# Altium schematic sheet Outputs Schematic.SchDoc("Outputs Schematic")
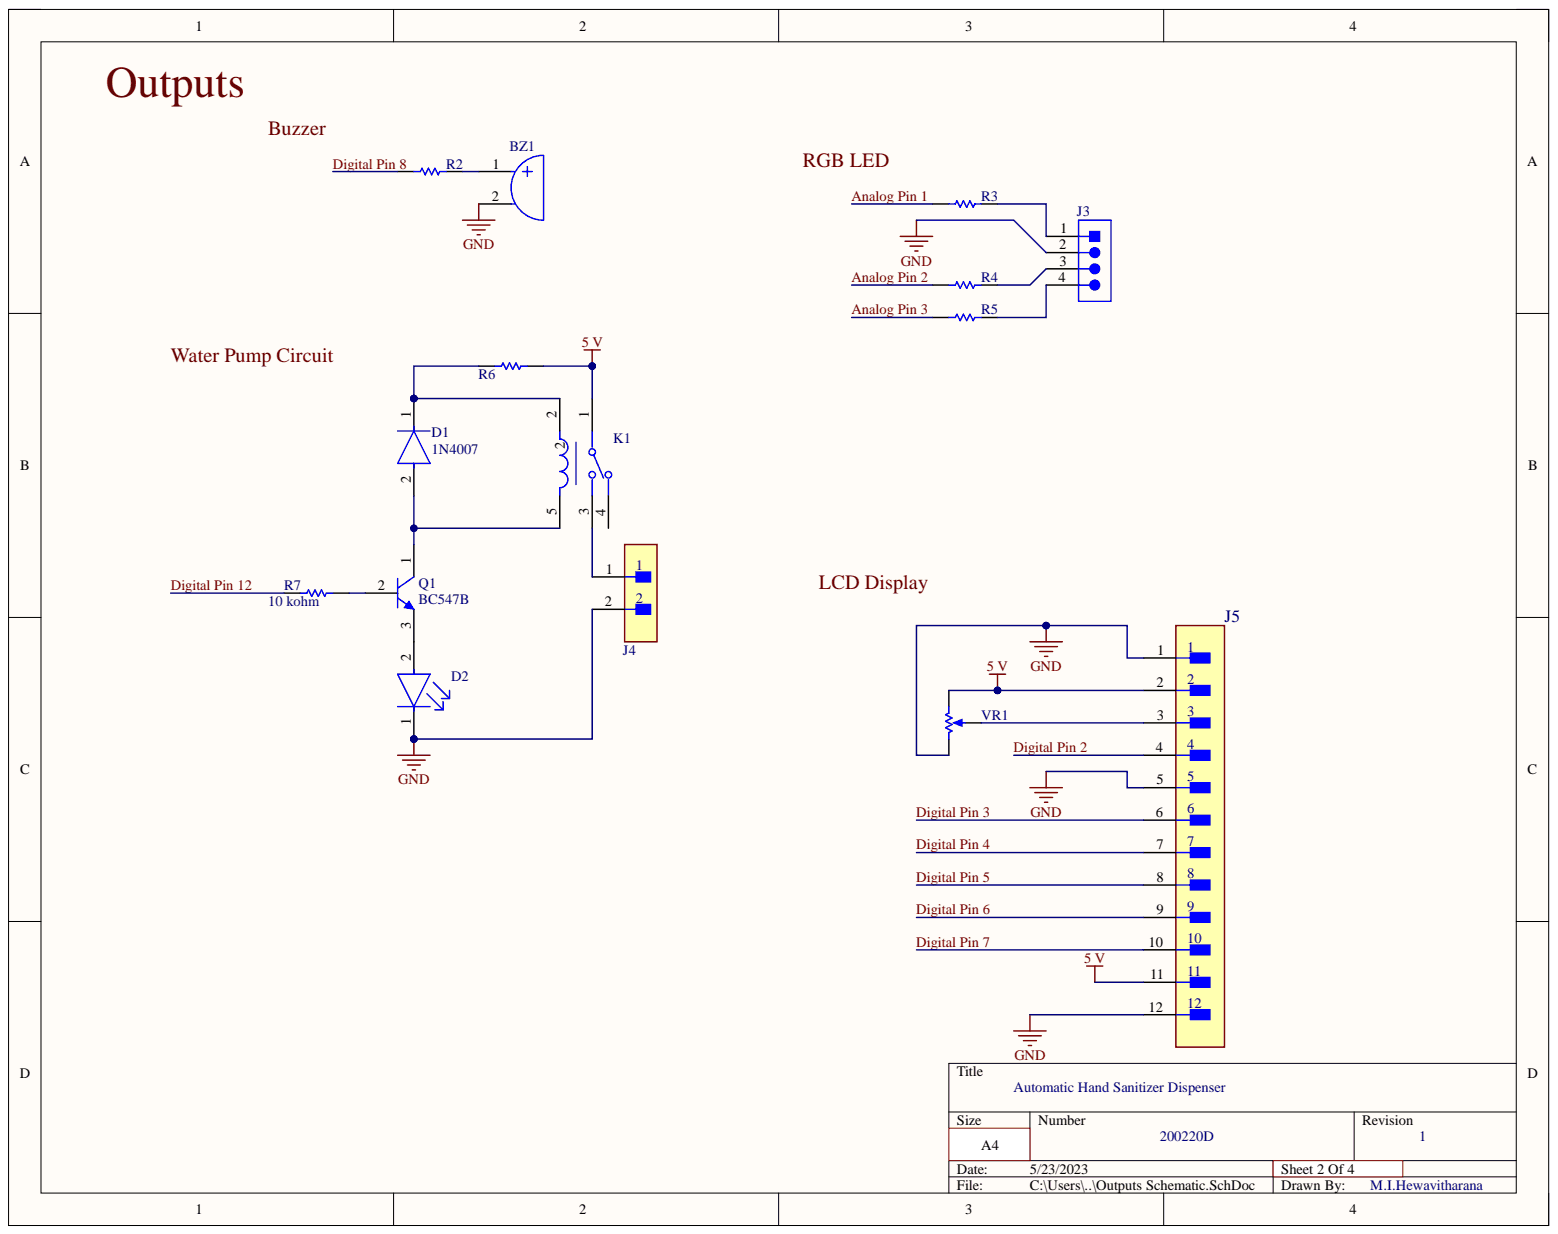
[diagram p0: Outputs Schematic.SchDoc]

## Parts
BZ1  KPEG242  [KINGS-KPEG242-2]  (value not drawn)
D1  1N4007  [FP-1N4007-MFG]
D2  SFH 4550  [FP-SFH_4550-MFG]  (value not drawn)
J3  640456-4  [TECO-640456-4]  (value not drawn)
J4  TSW-102-07-L-S  [FP-TSW-102-07-L-S-MFG]  (value not drawn)
J5  22-27-2121  [FP-22-27-2121-MFG]  (value not drawn)
K1  JS1-18V-F  [FP-JS1-18V-F-MFG]  (value not drawn)
Q1  BC547B  [ONSC-TO-92-3-29-11]
R2  MFR-25FBF52-1K  [RESA60-630X240]  (value not drawn)
R3  MFR-25FBF52-1K  [RESA60-630X240]  (value not drawn)
R4  MFR-25FBF52-1K  [RESA60-630X240]  (value not drawn)
R5  MFR-25FBF52-1K  [RESA60-630X240]  (value not drawn)
R6  MFR-25FBF52-1K  [RESA60-630X240]  (value not drawn)
R7  Resistance: 10 kohm  [RESA60-630X240]  (hidden comment: MFR-25FBF52-1K)
VR1  3852A-282-103AL  [BOUR-3852A-282-103AL-3_V]  (value not drawn)

## Nets
5 V: J5.2 J5.11 K1.1 R6.2 VR1.1
GND: BZ1.2 D2.1 J3.2 J4.2 J5.1 J5.5 J5.12 VR1.3
Analog Pin 1: R3.1
Analog Pin 2: R4.1
Analog Pin 3: R5.1
Digital Pin 2: J5.4
Digital Pin 3: J5.6
Digital Pin 4: J5.7
Digital Pin 5: J5.8
Digital Pin 6: J5.9
Digital Pin 7: J5.10
Digital Pin 8: R2.1
Digital Pin 12: R7.1
NetBZ1_1: BZ1.1 R2.2
NetD1_1: D1.1 K1.2 R6.1
NetD1_2: D1.2 K1.5 Q1.1
NetD2_2: D2.2 Q1.3
NetJ3_1: J3.1 R3.2
NetJ3_3: J3.3 R4.2
NetJ3_4: J3.4 R5.2
NetJ4_1: J4.1 K1.3
NetJ5_3: J5.3 VR1.2
NetQ1_2: Q1.2 R7.2

Not connected: K1 4

## Library data of the parts
BZ1 = CMP-2000-06518-1: Piezo Indicator, 4100 Hz, 3 to 16 V, -20 to 70 degC, 2-Pin THD, RoHS; Manufacturer: KingstateElectronicsCorp.; PartNumber: KPE-242; Current - Max: 7A; Frequency Range: 3600to4600Hz; Maximum Resonant Frequency: 4600Hz; Maximum Supply Current: 7mA; Minimum Sound Pressure: 70dBA; Product Diameter: 13.7mm; Product Height: 7.6mm; Tone Mode: Continuous; Typical Operating Supply Voltage: 12Vdc; Category: AudioIndicatorsandAlerts; Component Kind: Standard; Datasheet URL: https://datasheet.ciiva.com/11776/0900766b8068f3a2-11776713.pdf; Datasheet Version: 01/2006; Library Name: Altium Content Vault; Manufacturer URL: http://www.kingstate.com.tw/index.php/en/; Mounting: ThroughHole; Mounting Technology: ThroughHole; Operating Temperature: -20to70°C; Package Description: 2-Pin Through Hole Device, Body Dia 13.7 mm, Pitch 7.62 mm; Package Reference: KPEG242; Technology: Piezo; Termination Method: Pin; Type: Indicator
D1 = CMP-123542-000001-1: Rectifier diode 1000 V 1 A DO-41, ,; Manufacturer: Diotec; Capacitance: 15pF; Forward Current: 1A; Max Junction Temp: +175°C; Max Repetitive Reverse Voltage: 1000V; Max Surge Forward Current: 27A; Peak Reverse Current: 5uA; Value: 15pF; Automotive: No; Category: Diode; Component Kind: Standard; ComponentLink2Description: Datasheet; ComponentLink2URL: https://datasheet.octopart.com/1N4007-Diotec-datasheet-62346875.pdf; Device Class L1: Discrete Semiconductors; Device Class L2: Diodes; Device Class L3: Rectifier Diodes; Height: 2.8mm; Ipc Land Pattern Name: DIOAD888W100L520D280; Lead Free: Yes; Library Name: Altium Content Vault; Manufacturer Part Number: 1N4007; Octopart: https://octopart.com/1n4007-diotec-4040411; Package: 1N4007; Reverse Voltage: 1000V; RoHS: TRUE; Temperature: -50°C to +175°C; Temperature Range High: +175°C; Temperature Range Low: -50°C
D2 = CMP-62016-000011-1: EMITTER IR 860NM 100MA RADIAL; Manufacturer: Osram Opto; Colour: Infrared; Forward Voltage: 1.5V; Led Orientation: Straight; Reverse Voltage: 5V; Viewing Angle: 6°; Automotive: No; Category: Diode; Component Kind: Standard; ComponentLink2Description: Datasheet; ComponentLink2URL: https://dammedia.osram.info/media/resource/hires/osram-dam-5580407/SFH%204550_EN.pdf; Device Class L1: Optoelectronics; Device Class L2: LEDs; Device Class L3: unset; Digikey Description: EMITTER IR 860NM 100MA RADIAL; Forward Current: 100mA; Lead Free: Yes; Library Name: Altium Content Vault; Manufacturer Part Number: SFH 4550; Mouser Description: Infrared Emitters - High Power Infrared 850 nm HALF ANGLE +/-3DEG; Octopart: https://octopart.com/sfh+4550-osram+opto-55410894; Package: SFH 4550; Peak Emmision Wavelength: 860nm; RoHS: TRUE; Temperature: -40°C to +100°C; Temperature Range High: +100°C; Temperature Range Low: -40°C
J3 = CMP-2000-05419-1: Male Header, Pitch 2.54 mm, 1 x 4 Position, Height 10.03 mm, Tail Length 3.56 mm, RoHS, Bulk; Manufacturer: TE Connectivity; Agency Approvals: CSA,UL; Angle: Straight; Brand/Series: MTA-100Series; Color, Housing: White; Connector Type: MTA-100; Contact Centerline Spacing: 0.100    in.; Contact Termination Area Plating: Tin; Current, Rating: 5    A; Material, Contact: CopperAlloy; Material, Housing: Polyester; Mount Angle: Vertical; Mounting Hole Size: 0.04    "; Number of Contacts: 4; Pin Spacing: 0.1    "; Primary Type: InterconnectSystem; Special Features: Pinstrip; Standards: RoHSCompliant,ELVCompliant,UL94V-0; Temperature, Operating: -55to+105    degC; Voltage, Rating: 250    V; Color: White; Component Kind: Standard; Contact Mating Area Plating: Tin; Contact Plating: Tin; Contact Shape: Square; Datasheet URL: https://datasheet.ciiva.com/16286/eng-ds-82056-mta-0608-16286228.pdf; Datasheet Version: Dec-14; Flammability Rating: UL94V-0; Length, Overall: 0.4    "; Length, Pin: 0.14    "; Length, Terminal Post: 0.14    in.; Library Name: Altium Content Vault; Manufacturer URL: http://www.te.com/; Mounting Type: PCMount; Number of Positions: 4; Number of Rows: 1; Size, Post: 0.025    in.; Termination: Solder; Type: PCBPlug
J4 = CMP-02766-001428-1: CONN HEADER VERT 2POS 2.54MM; Connector Type: Male Pin; Current Rating: 7.6A; Gender: Male; Max Voltage Rating (AC): 550V; Number of Contacts: 2; Color: Black; Component Kind: Standard; Contact Material: Bronze; Contact Mating Length: 0.23inch; Contact Plating: Gold; Contact Type: Male Pin; Depth: 2.54mm; Housing Color: Black; Housing Material: Polyester; Lead Free: Lead Free; Length: 5.08mm; Library Name: Altium Content Vault; Max Current Rating: 7.6A; Max Operating Temperature: 125°C; Min Operating Temperature: -55°C; Mount: Through Hole; Number of Positions: 2; Number of Rows: 1; Orientation: Straight; Package Quantity: 1; Packaging: Bulk; Pitch: 2.54mm; Radiation Hardening: No; REACH SVHC: No SVHC; RoHS Compliant: Yes; Series: TSW; Termination: Solder; Voltage Rating: 550V; Width: 2.54mm
J5 = CMP-04776-000649-1: CONN HEADER VERT 12POS 2.54MM; Connector Type: Male Pin; Contact Resistance: 10mR; Current Rating: 4A; Gender: Male; Glow Wire Compliant: Non-compliant; Hole Diameter: 1.02mm; Insulation Resistance: 50GR; Number of Circuits: 12; Number of Lines: 1; Voltage Rating: 250V; Color: Natural; Component Kind: Standard; Contact Gender: Male; Contact Material: Brass; Contact Mating Length: 0.295inch; Contact Plating: Tin; Contact Type: Male Pin; Depth: 5.8mm; Fastening Type: Friction; Flammability Rating: UL94 V-0; Halogen Free: Low Halogen; Height: 11.7mm; Housing Color: White; Housing Material: Nylon; Lead Free: Lead Free; Lead Pitch: 2.54mm; Length: 30.48mm; Library Name: Altium Content Vault; Material: Nylon; Max Operating Temperature: 75°C; Min Operating Temperature: 0°C; Mount: Through Hole; Number of Contacts: 12; Number of Poles: 12; Number of Positions: 12; Number of Rows: 1; Orientation: Straight; Package Quantity: 10; Packaging: Bulk; Pitch: 2.54mm; Radiation Hardening: No; RoHS Compliant: Yes; Series: KK; Termination: Solder; Voltage Rating (AC): 250V; Voltage Rating (DC): 250V; Working Voltage: 250V
K1 = CMP-05607-000154-1: RELAY GEN PURPOSE SPDT 10A 18V; Coil Current: 20mA; Coil Resistance: 900R; Coil Type: Non-Latching; Max Voltage Rating (AC): 250V; Max Voltage Rating (DC): 100V; Operate Time: 10ms; Power Consumption: 360mW; Coil Voltage (DC): 18V; Component Kind: Standard; Contact Current Rating: 10A; Contact Material: Tin; Depth: 16mm; Height: 16mm; Length: 22mm; Library Name: Altium Content Vault; Max Current Rating: 10A; Max Operating Temperature: 70°C; Min Operating Temperature: -40°C; Mount: Through Hole; Number of Pins: 5; Package Quantity: 100; Packaging: Bulk; RoHS Compliant: Yes; Series: JS; Termination: PC Pin; Throw Configuration: SPDT; Voltage Rating (AC): 250V; Weight: 12g; Width: 12mm
Q1 = CMP-1048-01467-1: Amplifier Transistor, NPN Silicon, 3-Pin TO-92, Bulk Box; Manufacturer: On Semiconductor; fT Min (MHz): 150; IC Continuous (A): 0.1; Component Kind: Standard; ComponentLink1Description: Manufacturer URL; ComponentLink1URL: http://www.onsemi.com/; ComponentLink2Description: Datasheet; ComponentLink2URL: http://www.onsemi.com/pub_link/Collateral/BC546-D.PDF; ComponentLink3Description: Package Specification; ComponentLink3URL: http://www.onsemi.cn/pub_link/Collateral/2N6426-D.PDF; DatasheetVersion: Rev. 7; Library Name: Altium Content Vault; Mounting Technology: Through Hole; PackageDescription: 3-Pin Transistor Outline, Body 4.825 x 3.685 mm, Pitch 1.27 mm; PackageReference: TO-92-3-29-11_AM_SL; PackageVersion: Rev. AM; Packing: Bulk Box; PartNumber: BC547B; Polarity: NPN; RoHS: FALSE
R2-R6 = CMP-1659-00075-1: Axial Resistor, 1 KOhm, +/- 1%, 250 mW, -55 to 155 degC, 2-Pin THD, RoHS, Bulk; Power Rating: 250 mW; Resistance: 10 kohm; Voltage Rating: 250 V; Case\Package: Axial; Component Kind: Standard; ComponentLink1Description: Manufacturer URL; ComponentLink1URL: http://www.yageo.com/; ComponentLink2Description: Datasheet; ComponentLink2URL: http://www.yageo.com/documents/recent/Yageo%20LR_MFR_2013.pdf; DatasheetVersion: Apr-13; Length: 0.248 inch; Library Name: Altium Content Vault; Max Operating Temperature: 155 degC; Min Operating Temperature: -55 degC; Package Quantity: 200; PackageDescription: 2-Pin Axial Lead, Dia 2.4 mm, Body Length 6.3 mm; PackageReference: MFR-25; Packaging: Bulk; RoHSCompliant: true; Tolerance: 1%
R7 = CMP-1659-00075-1: Axial Resistor, 1 KOhm, +/- 1%, 250 mW, -55 to 155 degC, 2-Pin THD, RoHS, Bulk; Power Rating: 250 mW; Voltage Rating: 250 V; Case\Package: Axial; Component Kind: Standard; ComponentLink1Description: Manufacturer URL; ComponentLink1URL: http://www.yageo.com/; ComponentLink2Description: Datasheet; ComponentLink2URL: http://www.yageo.com/documents/recent/Yageo%20LR_MFR_2013.pdf; DatasheetVersion: Apr-13; Length: 0.248 inch; Library Name: Altium Content Vault; Max Operating Temperature: 155 degC; Min Operating Temperature: -55 degC; Package Quantity: 200; PackageDescription: 2-Pin Axial Lead, Dia 2.4 mm, Body Length 6.3 mm; PackageReference: MFR-25; Packaging: Bulk; RoHSCompliant: true; Tolerance: 1%
VR1 = CMP-2000-05079-1: Diameter Panel Control, 10 KOhm, +/- 10%, 2 W, -1 to 125 degC, 3-Pin THD, RoHS, Bulk; Manufacturer: Bourns; Actuator Diameter: 0.250"(6.35mm); Actuator Length: 0.875"(22.23mm); Actuator Type: Slotted; Associated Product: 36-8560-ND  -KNOBINSTRUMW/DIALRND.9"DIA  36-8555-ND  -KNOBINSTRUMSKIRTEDRND.7"DIA  36-8556-ND  -KNOBINSTRUMSKIRTEDRND.9"DIA  36-8565-ND  -KNOBINSTRUMDBLBARRND.7"DIA  36-8566-ND  -KNOBINSTRUMDBLBARRND.9"DIA  36-8568-ND  -KNOBINSTRUMSGLBARRND.7"DIA  36-8569-ND  -KNOBINSTRUMSGLBARRND.9"DIA  36-8559-ND  -KNOBINSTRUMW/DIALRND.7"DIA  226-1006-ND  -KNOBBLKGLOSS.75"DIA.250"SHAFT  226-1007-ND  -KNOBBLKGLOSS.925"DIA.250"SHAFT  226-1011-ND  -KNOBBLKGLOS; Built in Switch: None; Catalog Drawings: 3852SeriesSide1    3852SeriesSide2; Number of Gangs: 1; Online Catalog: 3852and3856Series; Other Names: 3852A282103AL; PCN Design/Specification: PBTResinJun/2011; PCN Other: MultipleChanges01/Dec/2012; Power (Watts): 2W; Resistance (Ohms): 10k; Resistive Material: Cermet; Rotation: 280deg; Temperature Coefficient: ±150ppm/degC; Bushing Thread: 3/8-32; Category: Potentiometers,VariableResistors; Component Kind: Standard; Datasheet URL: https://datasheet.ciiva.com/11831/0900766b80f93b4b-11831016.pdf; Datasheet Version: Sep-14; Family: RotaryPotentiometers,Rheostats; Library Name: Altium Content Vault; Manufacturer URL: http://www.bourns.com/; Mounting Type: PanelMount; Number of Turns: 1; Packaging: Bulk; RoHS Information: 3852A-LMaterialDeclaration; Series: 3852; Standard Package: 45; Taper: Linear; Termination Style: SolderLug; Tolerance: ±10%
